# Altium schematic sheet STM407VG.SchDoc(STM407VG)
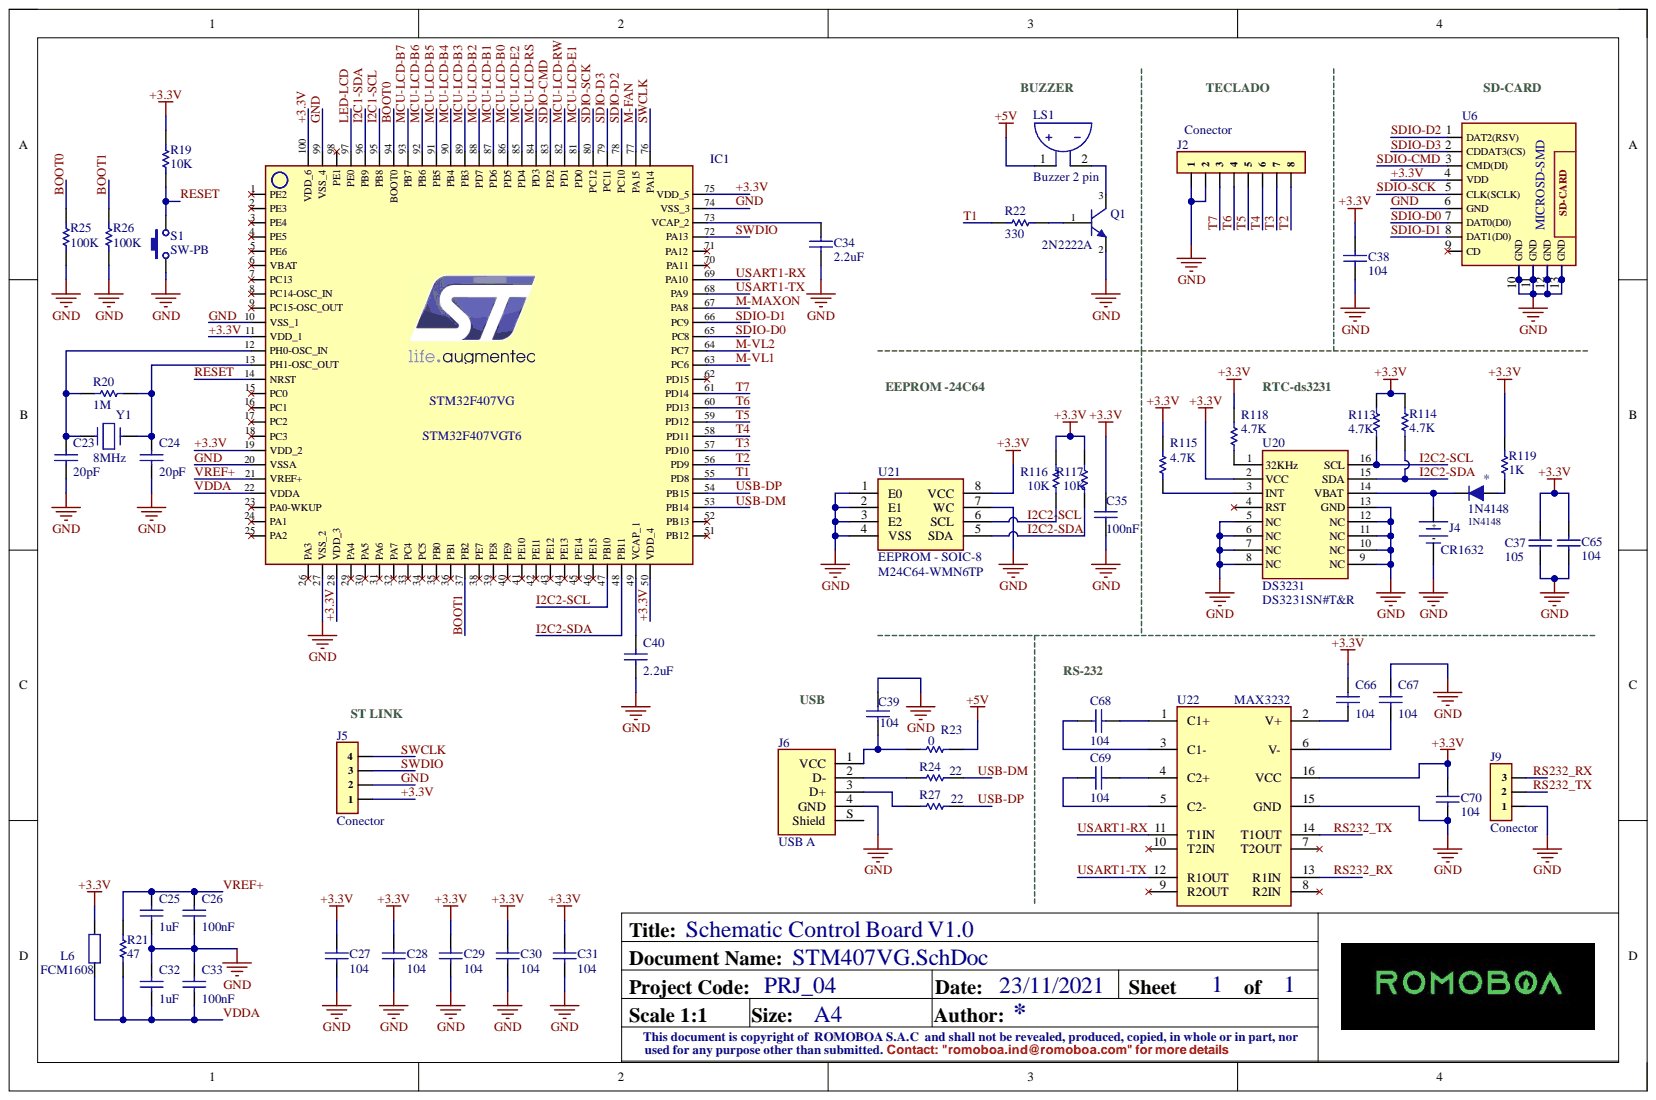
[diagram p0: STM407VG.SchDoc]

## Parts
*  1N4148  [1N4148- SOD80 -V2]
C23  20pF  [CAP0805]
C24  20pF  [CAP0805]
C25  1uF  [CAP0805]
C26  100nF  [CAP0805]
C27  104  [CAP0603]
C28  104  [CAP0603]
C29  104  [CAP0603]
C30  104  [CAP0603]
C31  104  [CAP0603]
C32  1uF  [CAP0805]
C33  100nF  [CAP0805]
C34  2.2uF  [CAP0603]
C35  100nF  [CAP0805]
C37  105  [CAP0805]
C38  104  [CAP0805]
C39  104  [CAP0805]
C40  2.2uF  [CAP0603]
C65  104  [CAP0805]
C66  104  [CAP0805]
C67  104  [CAP0805]
C68  104  [CAP0805]
C69  104  [CAP0805]
C70  104  [CAP0805]
IC1  STM32F407VG  Manufacturer Part Number: STM32F407VGT6  [STM32F407VG7: 100-TQFP - duplicate]
J2  Conector  [MOLEX KK 0022272081]
J4  CR1632  [BAT: CH224-2032]
J5  Conector  [1x4x2.54 Pin Header TH V]
J6  USB A  [USB-A-TH_USB-M-1]
J9  Conector  [MOLEX KK 0022272031]
L6  FCM1608  [RES: 0805R]
LS1  Buzzer 2 pin  [BUZ: AI-1223-TWT-3V-2-R]
Q1  2N2222A  [SOT-23]
R19  10K  [PRESICION CSR0805]
R20  1M  [PRESICION CSR0805]
R21  47  [PRESICION CSR0805]
R22  330  [PRESICION CSR0805]
R23  0  [PRESICION CSR0805]
R24  22  [PRESICION CSR0805]
R25  100K  [RES: 0603]
R26  100K  [RES: 0603]
R27  22  [PRESICION CSR0805]
R113  4.7K  [PRESICION CSR0805]
R114  4.7K  [PRESICION CSR0805]
R115  4.7K  [PRESICION CSR0805]
R116  10K  [PRESICION CSR0805]
R117  10K  [PRESICION CSR0805]
R118  4.7K  [PRESICION CSR0805]
R119  1K  [PRESICION CSR0805]
S1  SW-PB  [BUT: SMD MINI]
U6  MICROSD-SMD  [SD-CARD-SMD]
U20  DS3231  Manufacturer Part Number: DS3231SN#T&R  [SOIC-16 DS3231]
U21  EEPROM - SOIC-8  Category: EEPROM  Manufacturer Part Number: M24C64-WMN6TP  [MAX485: SOIC8]
U22  MAX3232  [MAX3232-CH34G-C: 16-SOIC]
Y1  8MHz  [XTAL SMD 8MHZ]

## Nets
+5V: LS1.1 R23.2
+3.3V: C27.2 C28.2 C29.2 C30.2 C31.2 C35.2 C37.2 C38.2 C65.2 C66.2 C70.2 IC1.11(VDD_1) IC1.19(VDD_2) IC1.28(VDD_3) IC1.50(VDD_4) IC1.75(VDD_5) IC1.100(VDD_6) J5.1 L6.2 R19.1 R113.2 R114.2 R115.2 R116.2 R117.2 R118.2 R119.1 U6.4(VDD) U20.2(VCC) U21.8(VCC) U22.16(VCC)
BOOT0: IC1.94(BOOT0) R25.2
BOOT1: IC1.37(PB2) R26.2
GND: C23.1 C24.1 C25.1 C26.1 C27.1 C28.1 C29.1 C30.1 C31.1 C32.2 C33.2 C34.1 C35.1 C37.1 C38.1 C39.1 C40.1 C65.1 C67.2 C70.1 IC1.10(VSS_1) IC1.20(VSSA) IC1.27(VSS_2) IC1.74(VSS_3) IC1.99(VSS_4) J2.1 J2.2 J4.2 J5.2 J6.4(GND) J9.1 Q1.2 R25.1 R26.1 S1.1 U6.6(GND) U6.10(GND) U6.11(GND) U6.12(GND) U6.13(GND) U20.5(NC) U20.6(NC) U20.7(NC) U20.8(NC) U20.9(NC) U20.10(NC) U20.11(NC) U20.12(NC) U20.13(GND) U21.1(E0) U21.2(E1) U21.3(E2) U21.4(VSS) U21.7(WC) U22.15(GND)
I2C1-SCL: IC1.95(PB8)
I2C1-SDA: IC1.96(PB9)
I2C2-SCL: IC1.47(PB10) R113.1 R116.1 U20.16(SCL) U21.6(SCL)
I2C2-SDA: IC1.48(PB11) R114.1 R117.1 U20.15(SDA) U21.5(SDA)
LED-LCD: IC1.97(PE0)
M-FAN: IC1.77(PA15)
M-MAXON: IC1.67(PA8)
M-VL1: IC1.63(PC6)
M-VL2: IC1.64(PC7)
MCU-LCD-B0: IC1.86(PD5)
MCU-LCD-B1: IC1.87(PD6)
MCU-LCD-B2: IC1.88(PD7)
MCU-LCD-B3: IC1.89(PB3)
MCU-LCD-B4: IC1.90(PB4)
MCU-LCD-B5: IC1.91(PB5)
MCU-LCD-B6: IC1.92(PB6)
MCU-LCD-B7: IC1.93(PB7)
MCU-LCD-E1: IC1.81(PD0)
MCU-LCD-E2: IC1.85(PD4)
MCU-LCD-RS: IC1.84(PD3)
MCU-LCD-RW: IC1.82(PD1)
RESET: IC1.14(NRST) R19.2 S1.2
RS232_RX: J9.3 U22.13(R1IN)
RS232_TX: J9.2 U22.14(T1OUT)
SDIO-CMD: IC1.83(PD2) U6.3(CMD(DI))
SDIO-D0: IC1.65(PC8) U6.7(DAT0(D0))
SDIO-D1: IC1.66(PC9) U6.8(DAT1(D0))
SDIO-D2: IC1.78(PC10) U6.1(DAT2(RSV))
SDIO-D3: IC1.79(PC11) U6.2(CDDAT3(CS))
SDIO-SCK: IC1.80(PC12) U6.5(CLK(SCLK))
SWCLK: IC1.76(PA14) J5.4
SWDIO: IC1.72(PA13) J5.3
T1: IC1.55(PD8) R22.2
T2: IC1.56(PD9) J2.8
T3: IC1.57(PD10) J2.7
T4: IC1.58(PD11) J2.6
T5: IC1.59(PD12) J2.5
T6: IC1.60(PD13) J2.4
T7: IC1.61(PD14) J2.3
USART1-RX: IC1.69(PA10) U22.11(T1IN)
USART1-TX: IC1.68(PA9) U22.12(R1OUT)
USB-DM: IC1.53(PB14) R24.2
USB-DP: IC1.54(PB15) R27.2
VDDA: C32.1 C33.1 IC1.22(VDDA) L6.1 R21.2
VREF+: C25.2 C26.2 IC1.21(VREF+) R21.1
Net*_1: *.1 R119.2
Net*_2: *.2 J4.1 U20.14(VBAT)
NetC23_2: C23.2 IC1.12(PH0-OSC_IN) R20.2 Y1.1
NetC24_2: C24.2 IC1.13(PH1-OSC_OUT) R20.1 Y1.2
NetC34_2: C34.2 IC1.73(VCAP_2)
NetC39_2: C39.2 J6.1(VCC) R23.1
NetC40_2: C40.2 IC1.49(VCAP_1)
NetC66_1: C66.1 U22.2(V+)
NetC67_1: C67.1 U22.6(V-)
NetC68_1: C68.1 U22.1(C1+)
NetC68_2: C68.2 U22.3(C1-)
NetC69_1: C69.1 U22.4(C2+)
NetC69_2: C69.2 U22.5(C2-)
NetJ6_2: J6.2(D-) R24.1
NetJ6_3: J6.3(D+) R27.1
NetLS1_2: LS1.2 Q1.3
NetQ1_1: Q1.1 R22.1
NetR115_1: R115.1 U20.3(INT)
NetR118_1: R118.1 U20.1(32KHz)

Not connected: IC1 1(PE2) 2(PE3) 3(PE4) 4(PE5) 5(PE6) 6(VBAT) 7(PC13) 8(PC14-OSC_IN) 9(PC15-OSC_OUT) 15(PC0) 16(PC1) 17(PC2) 18(PC3) 23(PA0-WKUP) 24(PA1) 25(PA2) 26(PA3) 29(PA4) 30(PA5) 31(PA6) 32(PA7) 33(PC4) 34(PC5) 35(PB0) 36(PB1) 38(PE7) 39(PE8) 40(PE9) 41(PE10) 42(PE11) 43(PE12) 44(PE13) 45(PE14) 46(PE15) 51(PB12) 52(PB13) 62(PD15) 70(PA11) 71(PA12) 98(PE1); J6 S(Shield); U6 9(CD); U20 4(RST); U22 7(T2OUT) 8(R2IN) 9(R2OUT) 10(T2IN)

## Library data of the parts
* = 1N4148: Diode Configuration:Single; Repetitive Reverse Voltage Vrrm Max:75V;200mA;; Manufacturer: NXP Semiconductors; Capacitance: 4pF; Current: 4A; Element Configuration: Single; Forward Voltage: 1V; Max Power Dissipation: 500mW; Max Repetitive Reverse Voltage (Vrrm): 100V; Polarity: Standard; Reverse Recovery Time: 4ns; Case/Package: SOD; Category: Rectifier Diodes; Component Kind: Standard; ComponentLink1Description: https://datasheet.ciiva.com/1179/1720485-1179297.pdf?src-supplier=Element14; ComponentLink1URL: https://datasheet.ciiva.com/1179/1720485-1179297.pdf?src-supplier=Element14; ComponentLink2Description: https://datasheet.ciiva.com/26921/getdatasheetpartid-764103-26921186.pdf?src-supplier=Verical; ComponentLink2URL: https://datasheet.ciiva.com/26921/getdatasheetpartid-764103-26921186.pdf?src-supplier=Verical; Current Rating: 200mA; Forward Current: 200mA; Height: 0.25inch; Lead Free: Lead Free; Length: 2.5inch; Library Name: DIODE.IntLib; Manufacturer Part Number: 1N4148; Max Forward Surge Current (Ifsm): 4A; Max Operating Temperature: 200°C; Min Operating Temperature: -65°C; Number of Pins: 2; Output Current: 200mA; Packaging: Ammo Pack; Peak Non-Repetitive Surge Current: 4A; Pricing 1: 50=0.01310, 500=0.00960, 1500=0.00900, 5000=0.00830, 25000=0.00800, 50000=0.00790, 50=0.01260, 500=0.00920, 1500=0.00860, 5000=0.00800, 25000=0.00770, 50000=0.00760, 50=0.06530, 500=0.04780, 1500=0.04460, 5000=0.04140, 25000=0.04000, 50000=0.03930, 50=0.06000, 500=0.04390, 1500=0.04100, 5000=0.03800, 25000=0.03670, 50000=0.03610, 50=0.00810, 500=0.00590, 1500=0.00550, 5000=0.00510, 25000=0.00490, 50000=0.00480, 50=0.00750, 500=0.00550, 1500=0.00510, 5000=0.00470, 25000=0.00460, 50000=0.00450, 50=0.07410, 500=0.05420, 1500=0.05060, 5000=0.04690, 25000=0.04530, 50000=0.04450, 50=1.01450, 500=0.74290, 1500=0.69290, 5000=0.64300, 25000=0.62090, 50000=0.60990, 50=0.03970, 500=0.02910, 1500=0.02710, 5000=0.02520, 25000=0.02430, 50000=0.02390, 50=0.71580, 500=0.52410, 1500=0.48880, 5000=0.45360, 25000=0.43810, 50000=0.43030, 50=0.08380, 500=0.06130, 1500=0.05720, 5000=0.05310, 25000=0.05130, 50000=0.05030, 50=0.01310, 500=0.00960, 1500=0.00890, 5000=0.00830, 25000=0.00800, 50000=0.00790, 50=0.00956, 500=0.00700, 1500=0.00653, 5000=0.00606, 25000=0.00585, 50000=0.00575 (AUD); Radiation Hardening: No; REACH SVHC: No SVHC; RoHS: Yes; Stock 1: 62500; Supplier 1: LCSC; Supplier Part Number 1: C258182; Termination: Axial; Voltage: 100V; Voltage Rating (DC): 100V; Weight: 0.01lb; Width: 0.25inch
C23-C35 C37-C40 C65-C70 = CAP_SMD_NP: CAPACITOR SMD NO POLARIZADO; Component Kind: Standard; Library Name: CAPACITORES.IntLib
IC1 = STM32F407VG: IC MCU 32BIT 1MB FLASH 100LQFP; Manufacturer: STMicroelectronics; Address Bus Width: 32b; Core Architecture: ARM; Frequency: 168MHz; Interface: I2C,SPI,CAN,I2S,UART,USB,Ethernet,IrDA,USART,LIN,EBI/EMI; Max Supply Voltage: 3.6V; Memory Size: 1024KB; Min Supply Voltage: 1.8V; Number of ADC Channels: 16; Number of Ethernet Channels: 1; Number of I2C Channels: 3; Number of I/Os: 82; Number of PWM Channels: 2; Number of Timers/Counters: 14; Oscillator Type: Internal; Peripherals: Brown-out Detect/Reset,POR,PWM,WDT,DMA,LCD,I2C; RAM Size: 196KB; Watchdog Timer: Yes; Case/Package: LQFP; Category: Microcontrollers; Component Kind: Standard; ComponentLink1Description: https://datasheet.ciiva.com/14132/stm32f407vgt6-tds-eng-14132567.pdf?src-supplier=Distrelec; ComponentLink1URL: https://datasheet.ciiva.com/14132/stm32f407vgt6-tds-eng-14132567.pdf?src-supplier=Distrelec; ComponentLink2Description: https://datasheet.ciiva.com/4842/dm00037051-492832-4842280.pdf?src-supplier=Mouser; ComponentLink2URL: https://datasheet.ciiva.com/4842/dm00037051-492832-4842280.pdf?src-supplier=Mouser; ComponentLink3Description: https://datasheet.ciiva.com/48687/001075599-da-01-en-mcu-32bt-1mb-stm32f407vgt6-lqfp-100-stm-48687547.pdf?src-supplier=Conrad; ComponentLink3URL: https://datasheet.ciiva.com/48687/001075599-da-01-en-mcu-32bt-1mb-stm32f407vgt6-lqfp-100-stm-48687547.pdf?src-supplier=Conrad; Data Bus Width: 32b; Height: 1.45mm; Lead Free: Lead Free; Length: 14.2mm; Max Frequency: 168MHz; Max Operating Temperature: 85°C; Memory Type: FLASH; Min Operating Temperature: -40°C; Number of Pins: 100; Number of Programmable I/O: 82; Number of SPI Channels: 3; Number of UART Channels: 2; Package Quantity: 90; Packaging: Tray; Pricing 1: 1=15.64010, 10=12.07130, 30=11.17920, 100=10.01930, 500=9.48400, 1000=9.39470, 1=15.36680, 10=11.86040, 30=10.98380, 100=9.84430, 500=9.31830, 1000=9.23060, 1=77.87020, 10=60.10180, 30=55.65990, 100=49.88510, 500=47.21990, 1000=46.77540, 1=74.43630, 10=57.45150, 30=53.20540, 100=47.68530, 500=45.13760, 1000=44.71270, 1=10.00790, 10=7.72430, 30=7.15340, 100=6.41120, 500=6.06870, 1000=6.01160, 1=8.77550, 10=6.77310, 30=6.27260, 100=5.62180, 500=5.32140, 1000=5.27130, 1=93.57010, 10=72.21930, 30=66.88180, 100=59.94270, 500=56.74020, 1000=56.20610, 1=1268.88510, 10=979.35190, 30=906.97120, 100=812.87110, 500=769.44270, 1000=762.19940, 1=49.02190, 10=37.83610, 30=35.03980, 100=31.40430, 500=29.72650, 1000=29.44670, 1=898.00670, 10=693.10030, 30=641.87550, 100=575.27960, 500=544.54480, 1000=539.41860, 1=101.18160, 10=78.09410, 30=72.32240, 100=64.81880, 500=61.35580, 1000=60.77820, 1=16.07470, 10=12.40680, 30=11.48980, 100=10.29770, 500=9.74760, 1000=9.65580, 1=12.06990, 10=9.31580, 30=8.62730, 100=7.73220, 500=7.31910, 1000=7.25020 (AUD); Radiation Hardening: No; REACH SVHC: No SVHC; RoHS: Yes; RoHS Compliant: Yes; Series: STM32 F4; Stock 1: 8330; Supplier 1: LCSC; Supplier Part Number 1: C12345; Width: 14.2mm; Library Name: IC-MICROCONTROLADORES.IntLib
J2 = HEADER 1X8: HEADER THT 2.54MM; Component Kind: Standard; Library Name: CONECTORES-HEADER.IntLib
J4 = BATTERY CR1632: DIP Battery 3V Holders; Component Kind: Standard; ComponentLink1Description: link CSCS; ComponentLink1URL: https://lcsc.com/product-detail/Battery-Holders-Clips-Contacts_CR2032battery_C70376.html; ComponentLink2Description: DATASHEET; ComponentLink2URL: https://datasheet.lcsc.com/szlcsc/1810281720_Q-J-BS-2-1_C70376.pdf; Library Name: JACK-USB.IntLib
J5 = HEADER 1X4: HEADER THT 2.54MM; Component Kind: Standard; Library Name: CONECTORES-HEADER.IntLib
J6 = USB 2.0 TYPE A: Component Kind: Standard; ComponentLink1Description: New link; ComponentLink1URL: https://www.lcsc.com/product-detail/span-style-background-color-ff0-USB-span-Connectors_Jing-Extension-of-the-Electronic-Co-901-141A1011D10100_C42665.html; Library Name: JACK-USB.IntLib
J9 = HEADER 1X3: HEADER THT 2.54MM; Component Kind: Standard; Library Name: CONECTORES-HEADER.IntLib
L6 = Res2-SMD: Component Kind: Standard; Library Name: RESISTOR-POT..IntLib
Q1 = MMBT2222A-SMD: Trans  BJT NPN 40V 0.6A; Manufacturer: Diodes; Collector Base Voltage (VCBO): 75V; Collector Emitter Saturation Voltage: 1V; Continuous Collector Current: 600mA; Current: 1A; Element Configuration: Single; Emitter Base Voltage (VEBO): 6V; Frequency: 300MHz; hFE Min: 100; Max Power Dissipation: 300mW; Number of Elements: 1; Power Dissipation: 350mW; Case/Package: SOT-23; Category: BJTs; Collector Emitter Breakdown Voltage: 40V; Collector Emitter Voltage (VCEO): 40V; Component Kind: Standard; ComponentLink1Description: https://datasheet.ciiva.com/15139/getdatasheetpartid-82692-15139078.pdf?src-supplier=Verical; ComponentLink1URL: https://datasheet.ciiva.com/15139/getdatasheetpartid-82692-15139078.pdf?src-supplier=Verical; ComponentLink2Description: https://datasheet.ciiva.com/11198/ds30041-11198965.pdf?src-supplier=Avnet; ComponentLink2URL: https://datasheet.ciiva.com/11198/ds30041-11198965.pdf?src-supplier=Avnet; ComponentLink3Description: https://datasheet.ciiva.com/16199/ds30041-16199045.pdf?src-supplier=Future+Electronics; ComponentLink3URL: https://datasheet.ciiva.com/16199/ds30041-16199045.pdf?src-supplier=Future+Electronics; ComponentLink4Description: https://datasheet.ciiva.com/972/ds30041-972550.pdf?src-supplier=Digi-Key; ComponentLink4URL: https://datasheet.ciiva.com/972/ds30041-972550.pdf?src-supplier=Digi-Key; ComponentLink5Description: https://datasheet.ciiva.com/972/ds30041-972551.pdf?src-supplier=Digi-Key; ComponentLink5URL: https://datasheet.ciiva.com/972/ds30041-972551.pdf?src-supplier=Digi-Key; ComponentLink6Description: https://datasheet.ciiva.com/972/ds30041-972552.pdf?src-supplier=Digi-Key; ComponentLink6URL: https://datasheet.ciiva.com/972/ds30041-972552.pdf?src-supplier=Digi-Key; ComponentLink7Description: https://datasheet.ciiva.com/11201/ds30041-11201864.pdf?src-supplier=Avnet; ComponentLink7URL: https://datasheet.ciiva.com/11201/ds30041-11201864.pdf?src-supplier=Avnet; ComponentLink8Description: https://datasheet.ciiva.com/16615/ds30041-16615211.pdf?src-supplier=Avnet+Europe; ComponentLink8URL: https://datasheet.ciiva.com/16615/ds30041-16615211.pdf?src-supplier=Avnet+Europe; ComponentLink9Description: https://datasheet.ciiva.com/8029/ds30041-8029709.pdf?src-supplier=Element14; ComponentLink9URL: https://datasheet.ciiva.com/8029/ds30041-8029709.pdf?src-supplier=Element14; Contact Plating: Tin,Matte; Current Rating: 600mA; Gain Bandwidth Product: 300MHz; Height: 1mm; Lead Free: Lead Free; Length: 3.05mm; Library Name: TRANSISTORES.IntLib; Manufacturer Part Number: MMBT2222A; Max Breakdown Voltage: 40V; Max Collector Current: 600mA; Max Frequency: 300MHz; Max Operating Temperature: 150°C; Min Operating Temperature: -55°C; Mount: Surface Mount; Number of Pins: 3; Package Quantity: 3000; Packaging: Tape and Reel; Polarity: NPN; Pricing 1: 20=0.02430, 200=0.01800, 600=0.01690, 2000=0.01570, 10000=0.01520, 20000=0.01500, 20=0.02280, 200=0.01700, 600=0.01590, 2000=0.01480, 10000=0.01430, 20000=0.01410, 20=0.11640, 200=0.08640, 600=0.08100, 2000=0.07550, 10000=0.07300, 20000=0.07180, 20=0.10910, 200=0.08110, 600=0.07590, 2000=0.07080, 10000=0.06850, 20000=0.06740, 20=0.01470, 200=0.01090, 600=0.01020, 2000=0.00950, 10000=0.00920, 20000=0.00900, 20=0.01340, 200=0.00990, 600=0.00930, 2000=0.00870, 10000=0.00840, 20000=0.00830, 20=0.13210, 200=0.09820, 600=0.09190, 2000=0.08570, 10000=0.08290, 20000=0.08160, 20=1.80040, 200=1.33760, 600=1.25260, 2000=1.16760, 10000=1.12980, 20000=1.11110, 20=0.07110, 200=0.05280, 600=0.04950, 2000=0.04610, 10000=0.04460, 20000=0.04390, 20=1.33250, 200=0.99000, 600=0.92710, 2000=0.86410, 10000=0.83620, 20000=0.82230, 20=0.15580, 200=0.11570, 600=0.10840, 2000=0.10100, 10000=0.09770, 20000=0.09610, 20=0.02350, 200=0.01750, 600=0.01630, 2000=0.01520, 10000=0.01470, 20000=0.01450, 20=0.01705, 200=0.01267, 600=0.01186, 2000=0.01106, 10000=0.01070, 20000=0.01052 (AUD); Radiation Hardening: No; REACH SVHC: No SVHC; RoHS: Yes; Stock 1: 6340; Supplier 1: LCSC; Supplier Part Number 1: C94515; Termination: SMD/SMT; Transition Frequency: 300MHz; Voltage: 40V; Voltage Rating (DC): 40V; Weight: 0.000282oz; Width: 1.4mm
R19-R27 R113-R119 = Res1-SMD: Component Kind: Standard; Library Name: RESISTOR-POT..IntLib
S1 = Button2 THT-SMD_4P: BUTTTON 4P SMD-THT 50mA - 12VDC; Manufacturer: ITT C&K; Manufacturer Part Number: PTS645SM43SMTR92LFS; Actuator Length: 0.85mm; Actuator Material: Nylon,Polyamide; Actuator Type: Standard; Contact Resistance: 100mR; Electrical Life: 1000000Cycles; Illumination Type: Non-Illuminated,Illuminated; Max Voltage Rating (DC): 12V; Mechanical Life: 100000Cycles; Number of Poles: 1; Operating Force: 160gf; Switch Function: Off-Mom; Switch Travel: 0.25mm; Throw Configuration: SPST; Case/Package: SMD/SMT; Category: Tactile Switches; Color: Black; Component Kind: Standard; ComponentLink1Description: https://datasheet.ciiva.com/29931/getdatasheetpartid-764615-29931183.pdf?src-supplier=Verical; ComponentLink1URL: https://datasheet.ciiva.com/29931/getdatasheetpartid-764615-29931183.pdf?src-supplier=Verical; ComponentLink2Description: https://datasheet.ciiva.com/29903/getdatasheetpartid-786341-29903846.pdf?src-supplier=Verical; ComponentLink2URL: https://datasheet.ciiva.com/29903/getdatasheetpartid-786341-29903846.pdf?src-supplier=Verical; ComponentLink3Description: https://datasheet.ciiva.com/14920/pts645-14920734.pdf?src-supplier=Digi-Key; ComponentLink3URL: https://datasheet.ciiva.com/14920/pts645-14920734.pdf?src-supplier=Digi-Key; ComponentLink4Description: https://datasheet.ciiva.com/14920/pts645-14920733.pdf?src-supplier=Digi-Key; ComponentLink4URL: https://datasheet.ciiva.com/14920/pts645-14920733.pdf?src-supplier=Digi-Key; ComponentLink5Description: https://datasheet.ciiva.com/14920/pts645-14920735.pdf?src-supplier=Digi-Key; ComponentLink5URL: https://datasheet.ciiva.com/14920/pts645-14920735.pdf?src-supplier=Digi-Key; ComponentLink6Description: https://datasheet.ciiva.com/19453/162886-19453387.pdf?src-supplier=Jameco; ComponentLink6URL: https://datasheet.ciiva.com/19453/162886-19453387.pdf?src-supplier=Jameco; ComponentLink7Description: https://datasheet.ciiva.com/26883/getdatasheetpartid-388862-26883373.pdf?src-supplier=Verical; ComponentLink7URL: https://datasheet.ciiva.com/26883/getdatasheetpartid-388862-26883373.pdf?src-supplier=Verical; ComponentLink8Description: https://datasheet.ciiva.com/1701/en-b3f-1701027.pdf?src-supplier=Newark; ComponentLink8URL: https://datasheet.ciiva.com/1701/en-b3f-1701027.pdf?src-supplier=Newark; ComponentLink9Description: https://datasheet.ciiva.com/1532/en-b3f-1532548.pdf?src-supplier=Element14; ComponentLink9URL: https://datasheet.ciiva.com/1532/en-b3f-1532548.pdf?src-supplier=Element14; ComponentLink10Description: https://datasheet.ciiva.com/16154/en-b3f-16154887.pdf?src-supplier=Onlinecomponents.com; ComponentLink10URL: https://datasheet.ciiva.com/16154/en-b3f-16154887.pdf?src-supplier=Onlinecomponents.com; ComponentLink11Description: https://datasheet.ciiva.com/2899/b3f-0811-2899719.pdf?src-supplier=Digi-Key; ComponentLink11URL: https://datasheet.ciiva.com/2899/b3f-0811-2899719.pdf?src-supplier=Digi-Key; ComponentLink12Description: https://datasheet.ciiva.com/14142/b3f-eng-datasheet-14142455.pdf?src-supplier=Distrelec; ComponentLink12URL: https://datasheet.ciiva.com/14142/b3f-eng-datasheet-14142455.pdf?src-supplier=Distrelec; ComponentLink13Description: https://datasheet.ciiva.com/5283/b3f-0811-26083-5283547.pdf?src-supplier=Mouser; ComponentLink13URL: https://datasheet.ciiva.com/5283/b3f-0811-26083-5283547.pdf?src-supplier=Mouser; ComponentLink14Description: https://datasheet.ciiva.com/14539/70175266-14539084.pdf?src-supplier=Allied+Electronics; ComponentLink14URL: https://datasheet.ciiva.com/14539/70175266-14539084.pdf?src-supplier=Allied+Electronics; ComponentLink15Description: https://datasheet.ciiva.com/20148/78-0136-20148399.pdf?src-supplier=Rapid+Electronics; ComponentLink15URL: https://datasheet.ciiva.com/20148/78-0136-20148399.pdf?src-supplier=Rapid+Electronics; Contact Current Rating: 50mA; Contact Material: Silver; Contact Plating: Silver; Current Rating: 50mA; Depth: 9mm; Height: 3.4mm; Insulation Resistance: 100MR; Lead Free: Lead Free; Length: 6mm; Library Name: BUTTON-SWITCH.IntLib; Max Current Rating: 50mA; Max Operating Temperature: 60°C; Min Operating Temperature: -20°C; Mount: Surface Mount; Number of Pins: 4; Orientation: Straight; Package Quantity: 1; Packaging: Tape and Reel; Pricing 1: 1=0.22900, 10=0.17310, 30=0.16280, 100=0.15250, 500=0.14800, 1000=0.14570, 1=0.21550, 10=0.16290, 30=0.15320, 100=0.14350, 500=0.13920, 1000=0.13710, 1=1.09830, 10=0.83010, 30=0.78090, 100=0.73150, 500=0.70970, 1000=0.69890, 1=1.03020, 10=0.77860, 30=0.73240, 100=0.68610, 500=0.66570, 1000=0.65550, 1=0.13840, 10=0.10460, 30=0.09840, 100=0.09220, 500=0.08940, 1000=0.08810, 1=0.12630, 10=0.09540, 30=0.08980, 100=0.08410, 500=0.08160, 1000=0.08040, 1=1.24740, 10=0.94280, 30=0.88680, 100=0.83080, 500=0.80600, 1000=0.79370, 1=16.99490, 10=12.84520, 30=12.08310, 100=11.31940, 500=10.98150, 1000=10.81430, 1=0.67140, 10=0.50750, 30=0.47740, 100=0.44720, 500=0.43380, 1000=0.42720, 1=12.57780, 10=9.50660, 30=8.94260, 100=8.37740, 500=8.12730, 1000=8.00360, 1=1.47020, 10=1.11120, 30=1.04530, 100=0.97920, 500=0.95000, 1000=0.93550, 1=0.22180, 10=0.16770, 30=0.15770, 100=0.14770, 500=0.14330, 1000=0.14120, 1=0.16095, 10=0.12165, 30=0.11443, 100=0.10720, 500=0.10400, 1000=0.10242 (AUD); Pricing 2: 1=0.18380, 10=0.13270, 30=0.12340, 100=0.11400, 500=0.10980, 1000=0.10780, 1=0.17290, 10=0.12490, 30=0.11610, 100=0.10720, 500=0.10330, 1000=0.10140, 1=0.88130, 10=0.63650, 30=0.59160, 100=0.54660, 500=0.52670, 1000=0.51680, 1=0.82660, 10=0.59710, 30=0.55490, 100=0.51270, 500=0.49400, 1000=0.48470, 1=0.11100, 10=0.08020, 30=0.07450, 100=0.06890, 500=0.06640, 1000=0.06510, 1=0.10130, 10=0.07320, 30=0.06800, 100=0.06280, 500=0.06060, 1000=0.05940, 1=1.00090, 10=0.72290, 30=0.67190, 100=0.62080, 500=0.59820, 1000=0.58690, 1=13.63710, 10=9.84980, 30=9.15470, 100=8.45780, 500=8.14980, 1000=7.99670, 1=0.53870, 10=0.38910, 30=0.36170, 100=0.33410, 500=0.32200, 1000=0.31590, 1=10.09270, 10=7.28980, 30=6.77530, 100=6.25960, 500=6.03160, 1000=5.91830, 1=1.17970, 10=0.85210, 30=0.79200, 100=0.73170, 500=0.70500, 1000=0.69180, 1=0.17800, 10=0.12860, 30=0.11950, 100=0.11040, 500=0.10640, 1000=0.10440, 1=0.12915, 10=0.09328, 30=0.08670, 100=0.08010, 500=0.07718, 1000=0.07573 (AUD); REACH SVHC: No SVHC; RoHS: Yes; Stock 1: 3092; Stock 2: 14563; Supplier 1: LCSC; Supplier 2: LCSC; Supplier Part Number 1: C221880; Supplier Part Number 2: C93157; Termination: Gull Wing; Voltage Rating (DC): 12V; Weight: 0.008818oz; Width: 6mm
U6 = MICROSD-SMD: microSD™ Memory Card 8 connector&Ejector SMD Card Sockets; Component Kind: Standard; ComponentLink1Description: New link; ComponentLink1URL: https://lcsc.com/product-detail/Card-Sockets-Connectors_Korean-Hroparts-Elec-TF-01A_C91145.html; Library Name: MODULOS-CIRCUIT.IntLib
U20 = DS3231: DS3231 Series 5.5V Extremely Accurate I2C-Integrated RTC/TCXO/Crystal - SOIC-16; Manufacturer: Maxim; Date Format: YY-MM-DD-dd; Interface: I2C,Serial,2-Wire; Logic Function: Clock; Min Supply Voltage: 2.3V; Operating Supply Voltage: 3.3V; Time Format: HH:MM:SS; Case/Package: SOIC; Category: Real Time Clocks; Component Kind: Standard; ComponentLink1Description: https://datasheet.ciiva.com/12291/ds3231-102175-12291260.pdf?src-supplier=Mouser; ComponentLink1URL: https://datasheet.ciiva.com/12291/ds3231-102175-12291260.pdf?src-supplier=Mouser; ComponentLink2Description: https://datasheet.ciiva.com/14244/ds3231-14244637.pdf?src-supplier=Avnet; ComponentLink2URL: https://datasheet.ciiva.com/14244/ds3231-14244637.pdf?src-supplier=Avnet; ComponentLink3Description: https://datasheet.ciiva.com/14244/ds3231-14244733.pdf?src-supplier=Avnet; ComponentLink3URL: https://datasheet.ciiva.com/14244/ds3231-14244733.pdf?src-supplier=Avnet; ComponentLink4Description: https://datasheet.ciiva.com/17307/ds3231-17307128.pdf?src-supplier=Avnet+Europe; ComponentLink4URL: https://datasheet.ciiva.com/17307/ds3231-17307128.pdf?src-supplier=Avnet+Europe; Contact Plating: Tin,Matte; Lead Free: Lead Free; Max Operating Temperature: 85°C; Max Supply Voltage: 5.5V; Min Operating Temperature: -40°C; Mount: Surface Mount; Number of Pins: 16; Package Quantity: 1000; Packaging: Tape and Reel; Pricing 1: 1=107,87820, 10=83,22210, 30=77,06130, 100=69,03950, 500=65,34300, 1000=64,72690, 1=104,23290, 10=80,41000, 30=74,45740, 100=66,70660, 500=63,13500, 1000=62,53980, 1=545,22390, 10=420,61060, 30=389,47350, 100=348,93040, 500=330,24810, 1000=327,13440, 1=517,66310, 10=399,34890, 30=369,78580, 100=331,29210, 500=313,55420, 1000=310,59790, 1=69,62110, 10=53,70890, 30=49,73290, 100=44,55590, 500=42,17030, 1000=41,77270, 1=60,51770, 10=46,68610, 30=43,23000, 100=38,72990, 500=36,65620, 1000=36,31060, 1=652,34990, 10=503,25240, 30=465,99750, 100=417,48840, 500=395,13540, 1000=391,40990, 1=9101,35430, 10=7021,19940, 30=6501,43140, 100=5824,65020, 500=5512,78940, 1000=5460,81260, 1=344,52100, 10=265,77920, 30=246,10400, 100=220,48520, 500=208,68010, 1000=206,71260, 1=6302,27910, 10=4861,86530, 30=4501,94930, 100=4033,30870, 500=3817,35910, 1000=3781,36750, 1=704,91980, 10=543,80720, 30=503,55010, 100=451,13190, 500=426,97760, 1000=422,95190, 1=111,49570, 10=86,01290, 30=79,64550, 100=71,35460, 500=67,53420, 1000=66,89740, 1=84,05000, 10=64,84000, 30=60,04000, 100=53,79000, 500=50,91000, 1000=50,43000 (AUD); Radiation Hardening: No; RoHS: Yes; RoHS Compliant: Yes; Series: -; Stock 1: 178; Supplier 1: LCSC; Supplier Part Number 1: C9866; Library Name: IC-COMUNICACION.IntLib
U21 = M24C64: M24C64 Series 64 Kb (8 K x 8) 2.5 V SMT Serial I²C Bus EEPROM - SOIC-8; Manufacturer: STMicroelectronics; Access Time: 900ns; Access Time-Max: 450ns; Density: 64Kb; Frequency: 1MHz; Interface: I2C,Serial,2-Wire; Max Frequency: 400kHz; Max Supply Voltage: 5.5V; Min Supply Voltage: 2.5V; Nominal Supply Current: 5mA; Number of Words: 8192; Operating Supply Voltage: 5V; Organization: 8KX8; Write Cycle Time-Max (tWC): 5ms; Write Protection: YES; Case/Package: SOIC; Component Kind: Standard; ComponentLink1Description: https://datasheet.ciiva.com/15123/getdatasheetpartid-110689-15123180.pdf?src-supplier=Verical; ComponentLink1URL: https://datasheet.ciiva.com/15123/getdatasheetpartid-110689-15123180.pdf?src-supplier=Verical; ComponentLink2Description: https://datasheet.ciiva.com/1905/cd00259166-1905030.pdf?src-supplier=Digi-Key; ComponentLink2URL: https://datasheet.ciiva.com/1905/cd00259166-1905030.pdf?src-supplier=Digi-Key; ComponentLink3Description: https://datasheet.ciiva.com/1905/cd00259166-1905031.pdf?src-supplier=Digi-Key; ComponentLink3URL: https://datasheet.ciiva.com/1905/cd00259166-1905031.pdf?src-supplier=Digi-Key; ComponentLink4Description: https://datasheet.ciiva.com/1905/cd00259166-1905032.pdf?src-supplier=Digi-Key; ComponentLink4URL: https://datasheet.ciiva.com/1905/cd00259166-1905032.pdf?src-supplier=Digi-Key; ComponentLink5Description: https://datasheet.ciiva.com/4859/cd00259166-69066-4859801.pdf?src-supplier=Mouser; ComponentLink5URL: https://datasheet.ciiva.com/4859/cd00259166-69066-4859801.pdf?src-supplier=Mouser; ComponentLink6Description: https://datasheet.ciiva.com/16201/cd00259166-16201405.pdf?src-supplier=Future+Electronics; ComponentLink6URL: https://datasheet.ciiva.com/16201/cd00259166-16201405.pdf?src-supplier=Future+Electronics; ComponentLink7Description: https://datasheet.ciiva.com/41455/001186012-da-01-en-ic-eepr-64kbit-m24c64-wmn6tp-soic-8-stm-41455099.pdf?src-supplier=Conrad; ComponentLink7URL: https://datasheet.ciiva.com/41455/001186012-da-01-en-ic-eepr-64kbit-m24c64-wmn6tp-soic-8-stm-41455099.pdf?src-supplier=Conrad; Height: 1.25mm; Lead Free: Lead Free; Length: 4.9mm; Max Operating Temperature: 85°C; Memory Size: 64Kb; Memory Type: EEPROM; Min Operating Temperature: -40°C; Mount: Surface Mount; Number of Pins: 8; Package Quantity: 1; Packaging: Tape and Reel; Programming Voltage: 5.5V; Radiation Hardening: No; REACH SVHC: No SVHC; RoHS: Yes; RoHS Compliant: Yes; Series: -; Supplier 1: LCSC; Supplier Part Number 1: C7999; Supply Current: 5mA; Supply Voltage-Nom: 5.5V; Width: 3.9mm; Library Name: IC-COMUNICACION.IntLib
U22 = MAX3232: MAX3232CDR  , 2-drivers, 3V-5.5V Supply, SOIC-16; Manufacturer: Texas Instruments; Data Rate: 250Kbps; ESD Protection: Yes; Logic Function: Transceiver,Receiver; Max Supply Current: 1mA; Max Supply Voltage: 5.5V; Min Supply Voltage: 3V; Number of Drivers: 2; Number of Transceivers: 1; Operating Supply Voltage: 5V; Output Voltage: 5.4V; Simplex/Duplex: Full Duplex; Case/Package: D; Category: RS-232 / RS-422 / RS-485 Interface ICs; Component Kind: Standard; ComponentLink1Description: https://datasheet.ciiva.com/41461/001186897-da-01-en-ic-drvr-rcvr-mult-max3232cdr-soic-16-tid-41461424.pdf?src-supplier=Conrad; ComponentLink1URL: https://datasheet.ciiva.com/41461/001186897-da-01-en-ic-drvr-rcvr-mult-max3232cdr-soic-16-tid-41461424.pdf?src-supplier=Conrad; ComponentLink2Description: https://datasheet.ciiva.com/1702/max3232-1702778.pdf?src-supplier=Digi-Key; ComponentLink2URL: https://datasheet.ciiva.com/1702/max3232-1702778.pdf?src-supplier=Digi-Key; ComponentLink3Description: https://datasheet.ciiva.com/1702/max3232-1702779.pdf?src-supplier=Digi-Key; ComponentLink3URL: https://datasheet.ciiva.com/1702/max3232-1702779.pdf?src-supplier=Digi-Key; ComponentLink4Description: https://datasheet.ciiva.com/1702/max3232-1702780.pdf?src-supplier=Digi-Key; ComponentLink4URL: https://datasheet.ciiva.com/1702/max3232-1702780.pdf?src-supplier=Digi-Key; ComponentLink5Description: https://datasheet.ciiva.com/46397/1882754-46397998.pdf?src-supplier=Element14; ComponentLink5URL: https://datasheet.ciiva.com/46397/1882754-46397998.pdf?src-supplier=Element14; Contact Plating: Gold; Height: 1.58mm; Lead Free: Lead Free; Length: 9.9mm; Manufacturer Part Number: MAX3232CDR; Max Operating Temperature: 85°C; Min Operating Temperature: -40°C; Mount: Surface Mount; Nominal Supply Current: 1mA; Number of Pins: 16; Number of Receivers: 2; Number of Transmitters: 2; Operating Supply Current: 1mA; Package Quantity: 1; Packaging: Tape and Reel; Pricing 1: 1=0.97470, 10=0.70430, 30=0.65450, 100=0.60710, 500=0.58340, 1000=0.57390, 1=0.91710, 10=0.66270, 30=0.61580, 100=0.57120, 500=0.54890, 1000=0.54000, 1=4.67430, 10=3.37780, 30=3.13890, 100=2.91150, 500=2.79780, 1000=2.75230, 1=4.38440, 10=3.16830, 30=2.94420, 100=2.73090, 500=2.62420, 1000=2.58150, 1=0.58890, 10=0.42560, 30=0.39550, 100=0.36680, 500=0.35250, 1000=0.34680, 1=0.53750, 10=0.38840, 30=0.36090, 100=0.33480, 500=0.32170, 1000=0.31650, 1=5.30870, 10=3.83620, 30=3.56500, 100=3.30660, 500=3.17750, 1000=3.12580, 1=72.32990, 10=52.26760, 30=48.57190, 100=45.05220, 500=43.29230, 1000=42.58840, 1=2.85750, 10=2.06490, 30=1.91890, 100=1.77980, 500=1.71030, 1000=1.68250, 1=53.53070, 10=38.68280, 30=35.94760, 100=33.34280, 500=32.04030, 1000=31.51930, 1=6.25720, 10=4.52160, 30=4.20190, 100=3.89740, 500=3.74520, 1000=3.68430, 1=0.94410, 10=0.68220, 30=0.63400, 100=0.58800, 500=0.56510, 1000=0.55590, 1=0.68500, 10=0.49500, 30=0.46000, 100=0.42667, 500=0.41000, 1000=0.40333 (AUD); Radiation Hardening: No; REACH SVHC: No SVHC; RoHS: Yes; Stock 1: 16175; Supplier 1: LCSC; Supplier Part Number 1: C45843; Weight: 0.004998oz; Width: 3.91mm; Library Name: IC-COMUNICACION.IntLib
Y1 = CRYSTAL: CRYSTAL 16.0000MHZ 20PF T/H; Manufacturer: Fox Electronics; Manufacturer Part Number: FOXSLF/160-20; ESR (Equivalent Series Resistance): 40R; Frequency: 16MHz; Frequency Stability: 50ppm; Frequency Tolerance: 30ppm; Category: Crystals; Component Kind: Standard; ComponentLink1Description: https://datasheet.ciiva.com/15116/getdatasheetpartid-567924-15116636.pdf?src-supplier=Verical; ComponentLink1URL: https://datasheet.ciiva.com/15116/getdatasheetpartid-567924-15116636.pdf?src-supplier=Verical; ComponentLink2Description: https://datasheet.ciiva.com/3174/hc49slf-3174197.pdf?src-supplier=Avnet; ComponentLink2URL: https://datasheet.ciiva.com/3174/hc49slf-3174197.pdf?src-supplier=Avnet; ComponentLink3Description: https://datasheet.ciiva.com/16437/hc49slf-16437657.pdf?src-supplier=Future+Electronics; ComponentLink3URL: https://datasheet.ciiva.com/16437/hc49slf-16437657.pdf?src-supplier=Future+Electronics; ComponentLink4Description: https://datasheet.ciiva.com/8047/hc49slf-8047740.pdf?src-supplier=Element14; ComponentLink4URL: https://datasheet.ciiva.com/8047/hc49slf-8047740.pdf?src-supplier=Element14; ComponentLink5Description: https://datasheet.ciiva.com/855/hc49slf-855677.pdf?src-supplier=Digi-Key; ComponentLink5URL: https://datasheet.ciiva.com/855/hc49slf-855677.pdf?src-supplier=Digi-Key; ComponentLink6Description: https://datasheet.ciiva.com/8047/hc49slf-8047631.pdf?src-supplier=Element14; ComponentLink6URL: https://datasheet.ciiva.com/8047/hc49slf-8047631.pdf?src-supplier=Element14; ComponentLink7Description: https://datasheet.ciiva.com/18404/70025550-18404527.pdf?src-supplier=Allied+Electronics; ComponentLink7URL: https://datasheet.ciiva.com/18404/70025550-18404527.pdf?src-supplier=Allied+Electronics; Depth: 4.65mm; Height: 3.6mm; Lead Free: Lead Free; Lead Pitch: 4.88mm; Length: 11.35mm; Load Capacitance: 20pF; Max Operating Temperature: 70°C; Min Operating Temperature: -20°C; Mount: Through Hole; Number of Pins: 2; Package Quantity: 100; Packaging: Bulk; Pricing 1: 1=0.34000, 10=0.27800, 50=0.25000, 100=0.22200, 500=0.21100, 1000=0.17800 (USD); Radiation Hardening: No; REACH SVHC: No SVHC; RoHS: Yes; Series Resistance: 40R; Stock 1: 650; Supplier 1: Mouser; Supplier Part Number 1: 559-FOXS160-20-LF; Termination: Radial; Tolerance: 50ppm; Width: 4.65mm; Library Name: CRYSTAL-RESONATOR.IntLib
LS1 = Buzzer 2 pin: Component Kind: Standard; Library Name: JACK-USB.IntLib
